# Altium schematic sheet PAGE4-COMMUNICATION INTERFACES.SchDoc
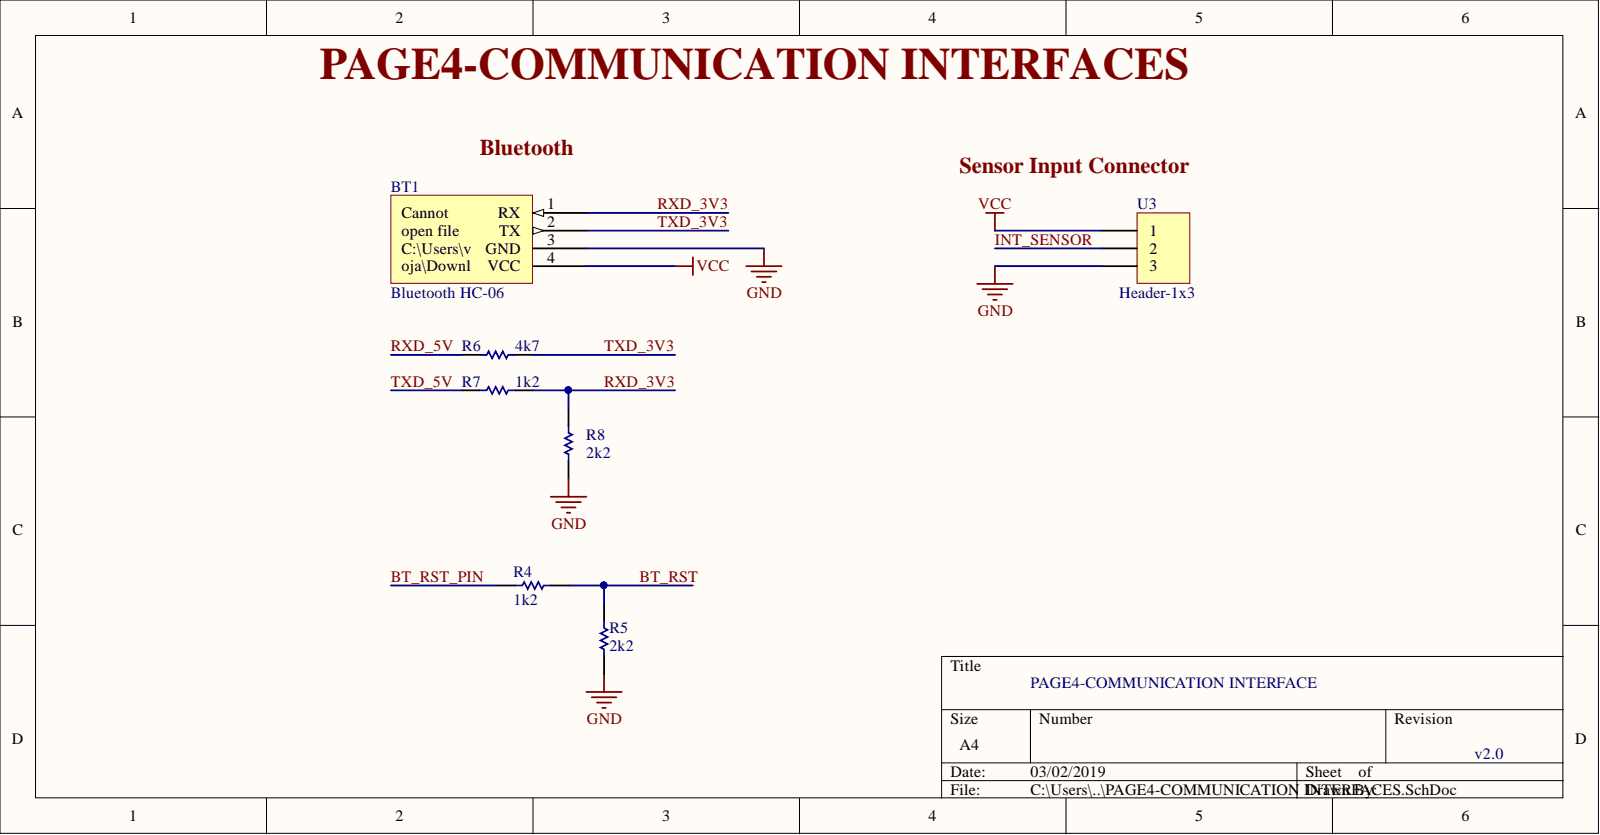
[diagram p0: PAGE4-COMMUNICATION INTERFACES.SchDoc]

## Parts
BT1  Bluetooth HC-06  [Bluetooth HC-06]
R4  1k2  [Res SMD 0805]
R5  2k2  [Res SMD 0805]
R6  4k7  [Res SMD 0805]
R7  1k2  [Res SMD 0805]
R8  2k2  [Res SMD 0805]
U3  Implementation: Header-1x3  [CTB1000/2]  (hidden comment: CTB1000/3)

## Nets
GND: BT1.3(GND) R5.1 R8.1 U3.3
VCC: BT1.4(VCC) U3.1
BT_RST: R4.2 R5.2
BT_RST_PIN: R4.1
INT_SENSOR: U3.2
RXD_3V3: BT1.1(RX) R7.2 R8.2
RXD_5V: R6.2
TXD_3V3: BT1.2(TX) R6.1
TXD_5V: R7.1

## Library data of the parts
BT1 = Bluetooth HC-06: HC Serial Bluetooth; Implementation: Yes; Component Kind: Standard; Datasheet: http://www.tec.reutlingen-university.de/uploads/media/DatenblattHC-05_BT-Modul.pdf; Library Name: ModulesLib_20150530.IntLib; Value: Bluetooth HC-06
R4-R8 = Res 1k: Resistor: thick film; SMD; 0805; 1kO; 0.125W; ±5%; -55÷125°C; Implementation: Yes; Manifacturer: ROYAL OHM; Manifacturer code: 0805S8J0102T5E; Ordering code: SMD0805-1K; Component Kind: Standard; Datasheet: http://www.tme.eu/en/Document/cd08025e8728292bb79d3f4577300b82/rezystor-smd.pdf; Distributor: TME.eu; Library Name: ResistorsLib_20150530.IntLib
  R4 R7: Value: 1k2
  R5 R8: Value: 2k2
  R6: Value: 4k7
U3 = CTB1000/3: CTB1000/3, PCB TERMINAL BLOCK, 5MM, 3WAY; Manufacturer: CAMDENBOSS; Ordering code: CN19746; Value: clamp  2pin; Component Kind: Standard; Datasheet: http://www.farnell.com/datasheets/1870880.pdf; Distributor: Farnell; Library Name: clamp_3pin_2015030.IntLib; Manufacturer code: CTB1000/3
